# Altium schematic sheet LPRAN.SchDoc(LPRAN)
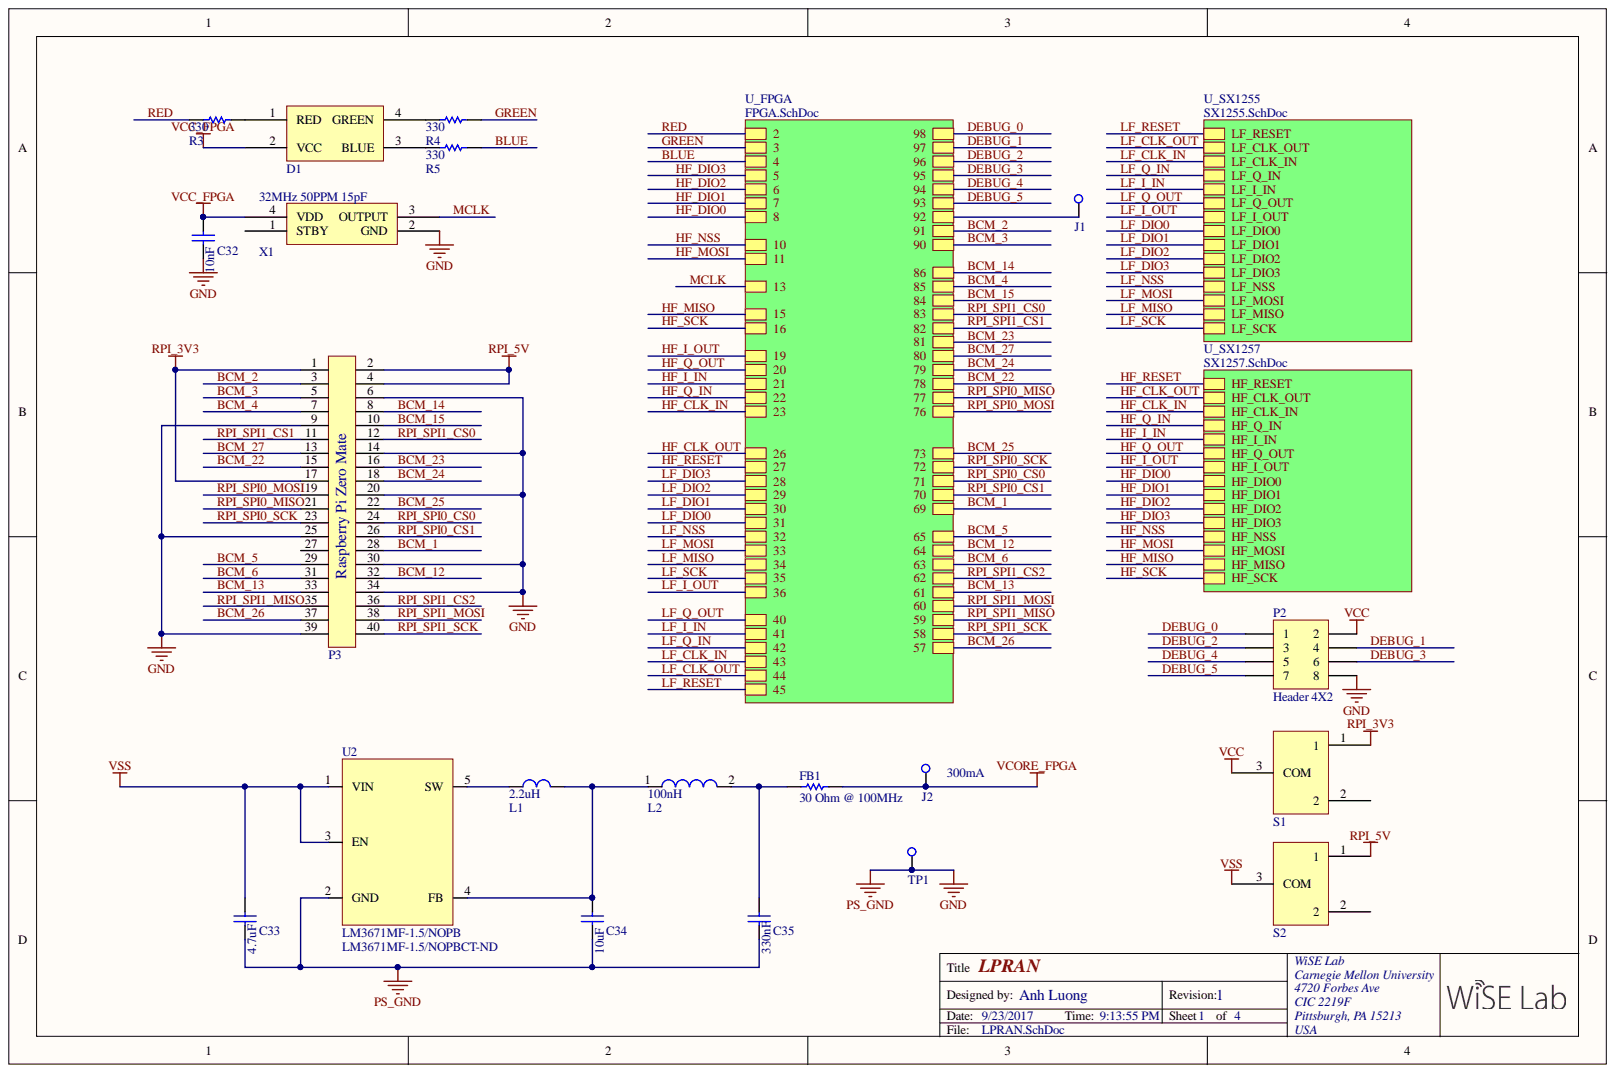
[diagram p0: LPRAN.SchDoc]

## Parts
C32  GRM155R71E103KA01D  [CAPC1005X04L]  (value not drawn)
C33  GRM188R60J475KE19D  [CAPC1608X08N (0603)]  (value not drawn)
C34  GRM188C81C106MA73D  [CAPC1608X08N (0603)]  (value not drawn)
C35  GRM188R71C334KA01D  [CAPC1608X08N (0603)]  (value not drawn)
D1  CLV1A-FKB-CJ1M1F1BB7R4S3  [CREE LV1]  (value not drawn)
FB1  Impedance @ Frequency: 30 Ohm @ 100MHz  [RESC1608X06N (0603)]  (hidden comment: MMZ1608R300A)
J1  5004  [TP]  (value not drawn)
J2  5004  [TP]  (value not drawn)
L1  LQH32CN2R2M33L  [INDC3225X22N]  (value not drawn)
L2  Inductance: 100nH  [INDC1608X08N]  (hidden comment: LQG18HNR10J00D)
P2  Header 4X2  [HDR2X4]
P3  Raspbery Pi Zero Mate  [Raspberry Pi Zero]  (value not drawn)
R3  MCR03ERTJ331  [RESC1608X06N (0603)]  (value not drawn)
R4  MCR03ERTJ331  [RESC1608X06N (0603)]  (value not drawn)
R5  MCR03ERTJ331  [RESC1608X06N (0603)]  (value not drawn)
S1  MA12R  [MA12R/TR]  (value not drawn)
S2  MA12R  [MA12R/TR]  (value not drawn)
TP1  CLIP_ON_TP  [CLIP_ON_TP]  (value not drawn)
U2  LM3671MF-1.5/NOPB  Supplier Part Number 1: LM3671MF-1.5/NOPBCT-ND  [SOT95P280X145-5N]
X1  ASE-32.000MHZ-L-C-T  [3.2x2.5x1.2mmXTAL]  (value not drawn)

## Nets
GND: C32.1 C33.1 C34.1 C35.1 P2.8 P3.6 P3.9 P3.14 P3.20 P3.25 P3.30 P3.34 P3.39 TP1.1 U2.2(GND) X1.2(GND)
RPI_3V3: P3.1 P3.17 S1.1
RPI_5V: P3.2 P3.4 S2.1
VCC: P2.2 S1.3
VCC_FPGA: C32.2 D1.2(VCC) X1.4(VDD)
VCORE_FPGA: FB1.1 J2.1
VSS: C33.2 S2.3 U2.1(VIN) U2.3(EN)
BCM_1: P3.28
BCM_2: P3.3
BCM_3: P3.5
BCM_4: P3.7
BCM_5: P3.29
BCM_6: P3.31
BCM_12: P3.32
BCM_13: P3.33
BCM_14: P3.8
BCM_15: P3.10
BCM_22: P3.15
BCM_23: P3.16
BCM_24: P3.18
BCM_25: P3.22
BCM_26: P3.37
BCM_27: P3.13
BLUE: R5.2
DEBUG_0: P2.1
DEBUG_1: P2.4
DEBUG_2: P2.3
DEBUG_3: P2.6
DEBUG_4: P2.5
DEBUG_5: P2.7
GREEN: R4.2
MCLK: X1.3(OUTPUT)
RED: R3.1
RPI_SPI0_CS0: P3.24
RPI_SPI0_CS1: P3.26
RPI_SPI0_MISO: P3.21
RPI_SPI0_MOSI: P3.19
RPI_SPI0_SCK: P3.23
RPI_SPI1_CS0: P3.12
RPI_SPI1_CS1: P3.11
RPI_SPI1_CS2: P3.36
RPI_SPI1_MISO: P3.35
RPI_SPI1_MOSI: P3.38
RPI_SPI1_SCK: P3.40
NetC34_2: C34.2 L1.2 L2.1 U2.4(FB)
NetC35_2: C35.2 FB1.2 L2.2
NetD1_1: D1.1(RED) R3.2
NetD1_3: D1.3(BLUE) R5.1
NetD1_4: D1.4(GREEN) R4.1
NetJ1_1: J1.1
NetL1_1: L1.1 U2.5(SW)
NetP3_27: P3.27
NetS1_2: S1.2
NetS2_2: S2.2
NetX1_1: X1.1(STBY)
HF_CLK_IN:
HF_CLK_OUT:
HF_DIO0:
HF_DIO1:
HF_DIO2:
HF_DIO3:
HF_I_IN:
HF_I_OUT:
HF_MISO:
HF_MOSI:
HF_NSS:
HF_Q_IN:
HF_Q_OUT:
HF_RESET:
HF_SCK:
LF_CLK_IN:
LF_CLK_OUT:
LF_DIO0:
LF_DIO1:
LF_DIO2:
LF_DIO3:
LF_I_IN:
LF_I_OUT:
LF_MISO:
LF_MOSI:
LF_NSS:
LF_Q_IN:
LF_Q_OUT:
LF_RESET:
LF_SCK:

## Library data of the parts
C32 = GRM155R71E103KA01D: CAP CER 10000PF 25V X7R 0402; Manufacturer: Murata Electronics North America; Tolerance: ±10%; Voltage - Rated: 25V; Thickness (Max): 0.022" (0.55mm); Temperature Coefficient: X7R; Supplier Part Number 1: 490-1312-1-ND; Supplier 1: Digi-Key; Stock 1: 8083248; Size / Dimension: 0.039" L x 0.020" W (1.00mm x 0.50mm); RoHS: RoHS Compliant; Ratings: -; Pricing 1: 1=0.1, 10=0.013, 100=0.0056, 500=0.004, 1000=0.00315, 2500=0.00286, 5000=0.00263 (USD); Part Status: Active; Packaging: Cut Tape (CT); Package / Case: 0402 (1005 Metric); Operating Temperature: -55°C ~ 125°C; Mounting Type: Surface Mount, MLCC; Manufacturer Part Number: GRM155R71E103KA01D; Library Name: Passives.SchLib; Lead Style: -; Lead Spacing: -; Height - Seated (Max): -; Features: -; ComponentLink1URL: http://www.murata.com/~/media/webrenewal/support/library/catalog/products/capacitor/mlcc/c02e.pdf; ComponentLink1Description: http://www.murata.com/~/media/webrenewal/support/library/catalog/products/capacitor/mlcc/c02e.pdf; Component Kind: Standard; Category: Capacitors; Applications: General Purpose
C33 = GRM188R60J475KE19D: CAP CER 4.7UF 6.3V 10% X5R 0603; Manufacturer: Murata Electronics North America; Capacitance: 4.7µF; Voltage - Rated: 6.3V; Tolerance: ±10%; Thickness (Max): 0.035" (0.90mm); Temperature Coefficient: X5R; Supplier 1: Digi-Key; Stock 1: 446094; Size / Dimension: 0.063" L x 0.031" W (1.60mm x 0.80mm); RoHS: RoHS Compliant; Ratings: -; Pricing 1: 1=0.12, 10=0.08, 50=0.046, 100=0.038, 250=0.032, 500=0.0268, 1000=0.022 (USD); Packaging: Cut Tape (CT); Package / Case: 0603 (1608 Metric); Operating Temperature: -55°C ~ 85°C; Mounting Type: Surface Mount, MLCC; Manufacturer Part Number: GRM188R60J475KE19D; Lead Style: -; Lead Spacing: -; Height - Seated (Max): -; Features: -; ComponentLink1URL: http://www.murata.com/~/media/webrenewal/support/library/catalog/products/capacitor/mlcc/c02e.ashx; ComponentLink1Description: http://www.murata.com/~/media/webrenewal/support/library/catalog/products/capacitor/mlcc/c02e.ashx; Component Kind: Standard; Category: Capacitors; Applications: General Purpose; Library Name: Chronos.SchLib
C34 = GRM188C81C106MA73D: CAP CER 10UF 16V 20% X6S 0603; Manufacturer: Murata Electronics North America; Capacitance: 10µF; Voltage - Rated: 16V; Tolerance: ±20%; Thickness (Max): 0.031" (0.80mm); Temperature Coefficient: X6S; Supplier 1: Digi-Key; Stock 1: 59274; Size / Dimension: 0.063" L x 0.031" W (1.60mm x 0.80mm); RoHS: RoHS Compliant; Ratings: -; Pricing 1: 1=0.4, 10=0.349, 50=0.2118, 100=0.1744, 250=0.13952, 500=0.12708, 1000=0.11237 (USD); Packaging: Cut Tape (CT); Package / Case: 0603 (1608 Metric); Operating Temperature: -55°C ~ 105°C; Mounting Type: Surface Mount, MLCC; Manufacturer Part Number: GRM188C81C106MA73D; Lead Style: -; Lead Spacing: -; Height - Seated (Max): -; Features: -; ComponentLink1URL: http://www.murata.com/products/catalog/pdf/c02e.pdf; ComponentLink1Description: http://www.murata.com/products/catalog/pdf/c02e.pdf; Component Kind: Standard; Category: Capacitors; Applications: General Purpose; Library Name: Chronos.SchLib
C35 = GRM188R71C334KA01D: CAP CER 0.33UF 16V X7R 0603; Manufacturer: Murata Electronics North America; Tolerance: ±10%; Capacitance: 0.33µF; Voltage - Rated: 16V; Thickness (Max): 0.035" (0.90mm); Temperature Coefficient: X7R; Supplier Part Number 1: 490-3294-1-ND; Supplier 1: Digi-Key; Stock 1: 120176; Size / Dimension: 0.063" L x 0.031" W (1.60mm x 0.80mm); RoHS: RoHS Compliant; Ratings: -; Pricing 1: 1=0.13, 10=0.092, 50=0.053, 100=0.0437, 250=0.0368, 500=0.03082, 1000=0.0253 (USD); Packaging: Cut Tape (CT); Package / Case: 0603 (1608 Metric); Operating Temperature: -55°C ~ 125°C; Mounting Type: Surface Mount, MLCC; Manufacturer Part Number: GRM188R71C334KA01D; Lead Style: -; Lead Spacing: -; Height - Seated (Max): -; Features: -; ComponentLink1URL: http://www.murata.com/~/media/webrenewal/support/library/catalog/products/capacitor/mlcc/c02e.pdf; ComponentLink1Description: http://www.murata.com/~/media/webrenewal/support/library/catalog/products/capacitor/mlcc/c02e.pdf; Component Kind: Standard; Category: Capacitors; Applications: General Purpose; Library Name: Chronos.SchLib
D1 = CLV1A-FKB-CJ1M1F1BB7R4S3: LED RED/GREEN/BLUE PLCC4 SMD; Manufacturer: Cree Inc; Wavelength - Peak: 630nm, 527nm, 470nm; Wavelength - Dominant: 621.5nm, 530nm, 472.5nm; Voltage - Forward (Vf) (Typ): 2V Red, 3.2V Green, 3.2V Blue; Viewing Angle: 120°; Millicandela Rating: 675mcd Red, 1055mcd Green, 337mcd Blue; Lens Type: Diffused, White; Lens Style/Size: Round with Flat Top, 2.2mm; Current - Test: 20mA; Color: Red, Green, Blue (RGB); Supplier 1: Digi-Key; Stock 1: 254000; Size / Dimension: 3.20mm L x 2.80mm W; RoHS: RoHS Compliant; Pricing 1: 2000=0.354, 50000=0.329, 100000=0.307 (USD); Packaging: Tape & Reel (TR); Package / Case: 4-PLCC; Mounting Type: Surface Mount; Manufacturer Part Number: CLV1A-FKB-CJ1M1F1BB7R4S3; Luminous Flux @ Current - Test: -; Library Name: Passives.SchLib; Height: 2.10mm; ComponentLink1URL: http://www.cree.com/~/media/Files/Cree/LED%20Components%20and%20Modules/HB/Data%20Sheets/CLV1AFKB(874).pdf; ComponentLink1Description: http://www.cree.com/~/media/Files/Cree/LED%20Components%20and%20Modules/HB/Data%20Sheets/CLV1AFKB(874).pdf; Component Kind: Standard; Category: Optoelectronics
FB1 = MMZ1608R300A: FERRITE CHIP BEAD 30 OHM SMD; Manufacturer Part Number: MMZ1608R300ATA00; Manufacturer: TDK Corporation; Filter Type: Differential Mode - Single; DC Resistance (DCR): 50 mOhm Max; Current Rating: 1.5A; Supplier Part Number 1: 445-2181-2-ND; Supplier 1: Digi-Key; Stock 1: 52000; Size / Dimension: 0.063" L x 0.031" W (1.60mm x 0.80mm); RoHS: RoHS Compliant; Pricing 1: 4000=0.016, 8000=0.0145, 12000=0.014, 28000=0.013, 100000=0.01285 (USD); Packaging: Tape & Reel (TR); Package / Case: 0603 (1608 Metric); Mounting Type: Surface Mount; Height (Max): 0.037" (0.95mm); ComponentLink1URL: http://www.tdk.co.jp/tefe02/e9412_mmz.pdf; ComponentLink1Description: http://www.tdk.co.jp/tefe02/e9412_mmz.pdf; Component Kind: Standard; Category: Filters; Library Name: Chronos.SchLib
J1 J2 = 5004: TEST POINT PC MINI .040"D YELLOW; Manufacturer: Keystone Electronics; Type: PC Test Point, Miniature; Terminal: 0.010" x 0.020" (0.25mm x 0.51mm) Phosphor Bronze Wire, Silver Plated; Supplier 1: Digi-Key; Stock 1: 45761; RoHS: RoHS Compliant; Pricing 1: 1=0.34, 10=0.318, 50=0.232, 100=0.2228, 250=0.20008, 500=0.191, 1000=0.15916, 2500=0.14552, 5000=0.13643 (USD); Packaging: Bulk; Mounting Type: 0.040" (1.02mm) Hole Diameter; Material: Base, Nylon 46, UL 94V-0; Manufacturer Part Number: 5004; ComponentLink1URL: http://www.keyelco.com/product-pdf.cfm?p=1313; ComponentLink1Description: http://www.keyelco.com/product-pdf.cfm?p=1313; Component Kind: Standard; Color: Yellow; Category: Test and Measurement; Library Name: Chronos.SchLib
L1 = LQH32CN2R2M33L: FIXED IND 2.2UH 790MA 97 MOHM; Manufacturer: Murata Electronics North America; Type: Wirewound; Tolerance: ±20%; Shielding: Unshielded; Material - Core: Ferrite; Inductance: 2.2µH; Frequency - Test: 1MHz; Frequency - Self Resonant: 64MHz; DC Resistance (DCR): 97 mOhm; Supplier Device Package: 1210 (3225 Metric); Supplier 1: Digi-Key; Stock 1: 5783; Size / Dimension: 0.126" L x 0.098" W (3.20mm x 2.50mm); RoHS: RoHS Compliant; Q @ Freq: -; Pricing 1: 1=0.32, 10=0.291, 25=0.2584, 50=0.2262, 100=0.21, 250=0.1938, 500=0.18412, 750=0.16796, 1000=0.14858 (USD); Packaging: Cut Tape (CT); Package / Case: 1210 (3225 Metric); Operating Temperature: -40°C ~ 85°C; Mounting Type: Surface Mount; Manufacturer Part Number: LQH32CN2R2M33L; Height - Seated (Max): 0.086" (2.20mm); Current Rating: 790mA; Current - Saturation: -; ComponentLink1URL: http://search.murata.co.jp/Ceramy/image/img/PDF/ENG/L0080S0140LQH32C_23~33.pdf; ComponentLink1Description: http://search.murata.co.jp/Ceramy/image/img/PDF/ENG/L0080S0140LQH32C_23~33.pdf; Component Kind: Standard; Category: Inductors, Coils, Chokes; Library Name: Chronos.SchLib
L2 = LQG18HNR10J00D: INDUCTOR 100NH 300MA 0603; Manufacturer: Murata Electronics North America; Type: Multilayer; Tolerance: ±5%; Shielding: Unshielded; Q @ Freq: 12 @ 100MHz; Material - Core: Air; Frequency - Test: 100MHz; Frequency - Self Resonant: 800MHz; DC Resistance (DCR): 900 mOhm Max; Supplier Device Package: 0603 (1608 Metric); Supplier 1: Digi-Key; Stock 1: 8292; Size / Dimension: 0.063" L x 0.031" W (1.60mm x 0.80mm); RoHS: RoHS Compliant; Pricing 1: 1=0.26, 10=0.24, 25=0.216, 50=0.1872, 100=0.168, 250=0.156, 500=0.144, 750=0.1368, 1000=0.1248 (USD); Packaging: Cut Tape (CT); Package / Case: 0603 (1608 Metric); Operating Temperature: -40°C ~ 85°C; Mounting Type: Surface Mount; Manufacturer Part Number: LQG18HNR10J00D; Library Name: Passives.SchLib; Height - Seated (Max): 0.037" (0.95mm); Current Rating: 300mA; Current - Saturation: -; ComponentLink1URL: http://search.murata.co.jp/Ceramy/image/img/PDF/ENG/L0075S0083LQG18H.pdf; ComponentLink1Description: http://search.murata.co.jp/Ceramy/image/img/PDF/ENG/L0075S0083LQG18H.pdf; Component Kind: Standard; Category: Inductors, Coils, Chokes
P2 = Header 4X2: Header, 4-Pin, Dual row; Signal Integrity: Connector; Library Name: Miscellaneous Connectors.IntLib; LatestRevisionNote: Re-released for DXP Platform.; LatestRevisionDate: 17-Jul-2002; Component Kind: Standard; Publisher: Altium Limited
P3 = Raspberry Pi Zero Mate: The header and mechanical drawings for connnecting a Raspbery Pi Zero; Library Name: Connectors.SchLib; Component Kind: Standard
R3-R5 = MCR03ERTJ331: RES 330 OHM 1/10W 5% 0603 SMD; Manufacturer: Rohm Semiconductor; Temperature Coefficient: ±200ppm/°C; Resistance (Ohms): 330; Power (Watts): 0.1W, 1/10W; Tolerance: ±5%; Supplier Device Package: 0603 (1608 Metric); Supplier 1: Digi-Key; Stock 1: 12878; Size / Dimension: 0.063" L x 0.031" W (1.60mm x 0.80mm); RoHS: RoHS Compliant; Pricing 1: 1=0.1, 10=0.013, 25=0.0092, 100=0.0052, 250=0.00396, 500=0.00316, 1000=0.00233 (USD); Packaging: Digi-Reel®; Package / Case: 0603 (1608 Metric); Number of Terminations: 2; Manufacturer Part Number: MCR03ERTJ331; Library Name: Passives.SchLib; Height: 0.022" (0.55mm); Features: -; Composition: Thick Film; ComponentLink1URL: http://rohmfs.rohm.com/en/products/databook/datasheet/passive/resistor/chip_resistor/mcr_g.pdf; ComponentLink1Description: http://rohmfs.rohm.com/en/products/databook/datasheet/passive/resistor/chip_resistor/mcr_g.pdf; Component Kind: Standard; Category: Resistors
S1 S2 = MA12R: SWITCH SLIDE SPDT 300MA 4V; Manufacturer: Apem Inc.; Switch Travel: 1.50mm; Switch Function: On-On; Operating Force: 200gf; Electrical Life: 10,000 Cycles; Current Rating: 300mA (DC); Contact Timing: Not Specified; Actuator Type: Standard; Actuator Material: Liquid Crystal Polymer (LCP); Voltage Rating - DC: 4V; Voltage Rating - AC: -; Termination Style: Gull Wing; Supplier Part Number 1: 679-3555-ND; Supplier 1: Digi-Key; Stock 1: 235; RoHS: RoHS Compliant; Pricing 1: 1=0.86, 50=0.7224, 100=0.688, 500=0.5676, 1000=0.5504, 5000=0.4816 (USD); Part Status: Active; Packaging: Tube; Operating Temperature: -10°C ~ 60°C; Mounting Type: Surface Mount, Right Angle; Mechanical Life: -; Manufacturer Part Number: MA12R; Features: Board Guide; Contact Material: Beryllium Copper; Contact Finish: Silver; ComponentLink1URL: http://www.apem.com/files/apem/brochures/MA_MICRO_SLIDE.pdf; ComponentLink1Description: http://www.apem.com/files/apem/brochures/MA_MICRO_SLIDE.pdf; Component Kind: Standard; Circuit: SPDT; Category: Switches; Actuator Length: 1.50mm; Library Name: Actuators.schlib
U2 = LM3671MF-1.5/NOPB: IC REG BUCK 1.5V 0.6A SYNC SOT23; Manufacturer: Texas Instruments; Voltage - Input (Min): 2.7V; Voltage - Input (Max): 5.5V; Synchronous Rectifier: Yes; Output Type: Fixed; Output Configuration: Positive; Number of Outputs: 1; Function: Step-Down; Frequency - Switching: 2MHz; Current - Output: 600mA; Voltage - Output (Min/Fixed): 1.5V; Voltage - Output (Max): -; Topology: Buck; Supplier Device Package: SOT-23-5; Supplier 1: Digi-Key; Stock 1: 845; RoHS: RoHS Compliant; Pricing 1: 1=1.47, 10=1.313, 100=1.0234, 500=0.84542 (USD); Part Status: Active; Packaging: Cut Tape (CT); Package / Case: SC-74A, SOT-753; Operating Temperature: -40°C ~ 85°C (TA); Mounting Type: Surface Mount; Manufacturer Part Number: LM3671MF-1.5/NOPB; ComponentLink1URL: http://www.ti.com/lit/ds/symlink/lm3671.pdf; ComponentLink1Description: http://www.ti.com/lit/ds/symlink/lm3671.pdf; Component Kind: Standard; Category: Integrated Circuits (ICs); Library Name: Actives.SchLib
X1 = ASE-32.000MHZ-L-C-T: Standard Clock Oscillators 32.000M 3.3V 50ppm; Manufacturer: ABRACON; Output Format: CMOS; Load Capacitance: 15 pF; Frequency: 32 MHz; Width: 2.5 mm; Type: XO - Crystal Clock Oscillators; Termination Style: SMD/SMT; Supplier Part Number 1: 815-ASE-32.000MLCT; Supplier 1: Mouser; Stock 1: 0; Standard Pack Qty: 1000; Series: ASE; RoHS - Mouser: Y; RoHS: RoHS Compliant; Reeling: True; Product: Standard Clock Oscillators; Pricing 1: 1000=0.959, 2000=0.910, 5000=0.878, 10000=0.845 (USD); Packaging: Reel; Package / Case: 3.2 mm x 2.5 mm; Operating Supply Voltage: 3.3 V; Mounting Style: SMD/SMT; Minimum Order Quantity: 1000; Minimum Operating Temperature: - 40 C; Maximum Operating Temperature: + 85 C; Manufacturer Part Number: ASE-32.000MHZ-L-C-T; Library Name: OSC.SchLib; Length: 3.2 mm; Height: 1 mm; Frequency Stability: 50 PPM; ComponentLink1URL: http://www.mouser.com/ds/2/3/ASEseries-38758.pdf; ComponentLink1Description: http://www.mouser.com/ds/2/3/ASEseries-38758.pdf; Component Kind: Standard; Category: Standard Clock Oscillators; Brand: ABRACON
TP1 = CLIP_ON_TP: Library Name: Connectors.SchLib; Component Kind: Standard
